# Altium schematic sheet ShieldESCv2-Cuadripolos.SchDoc
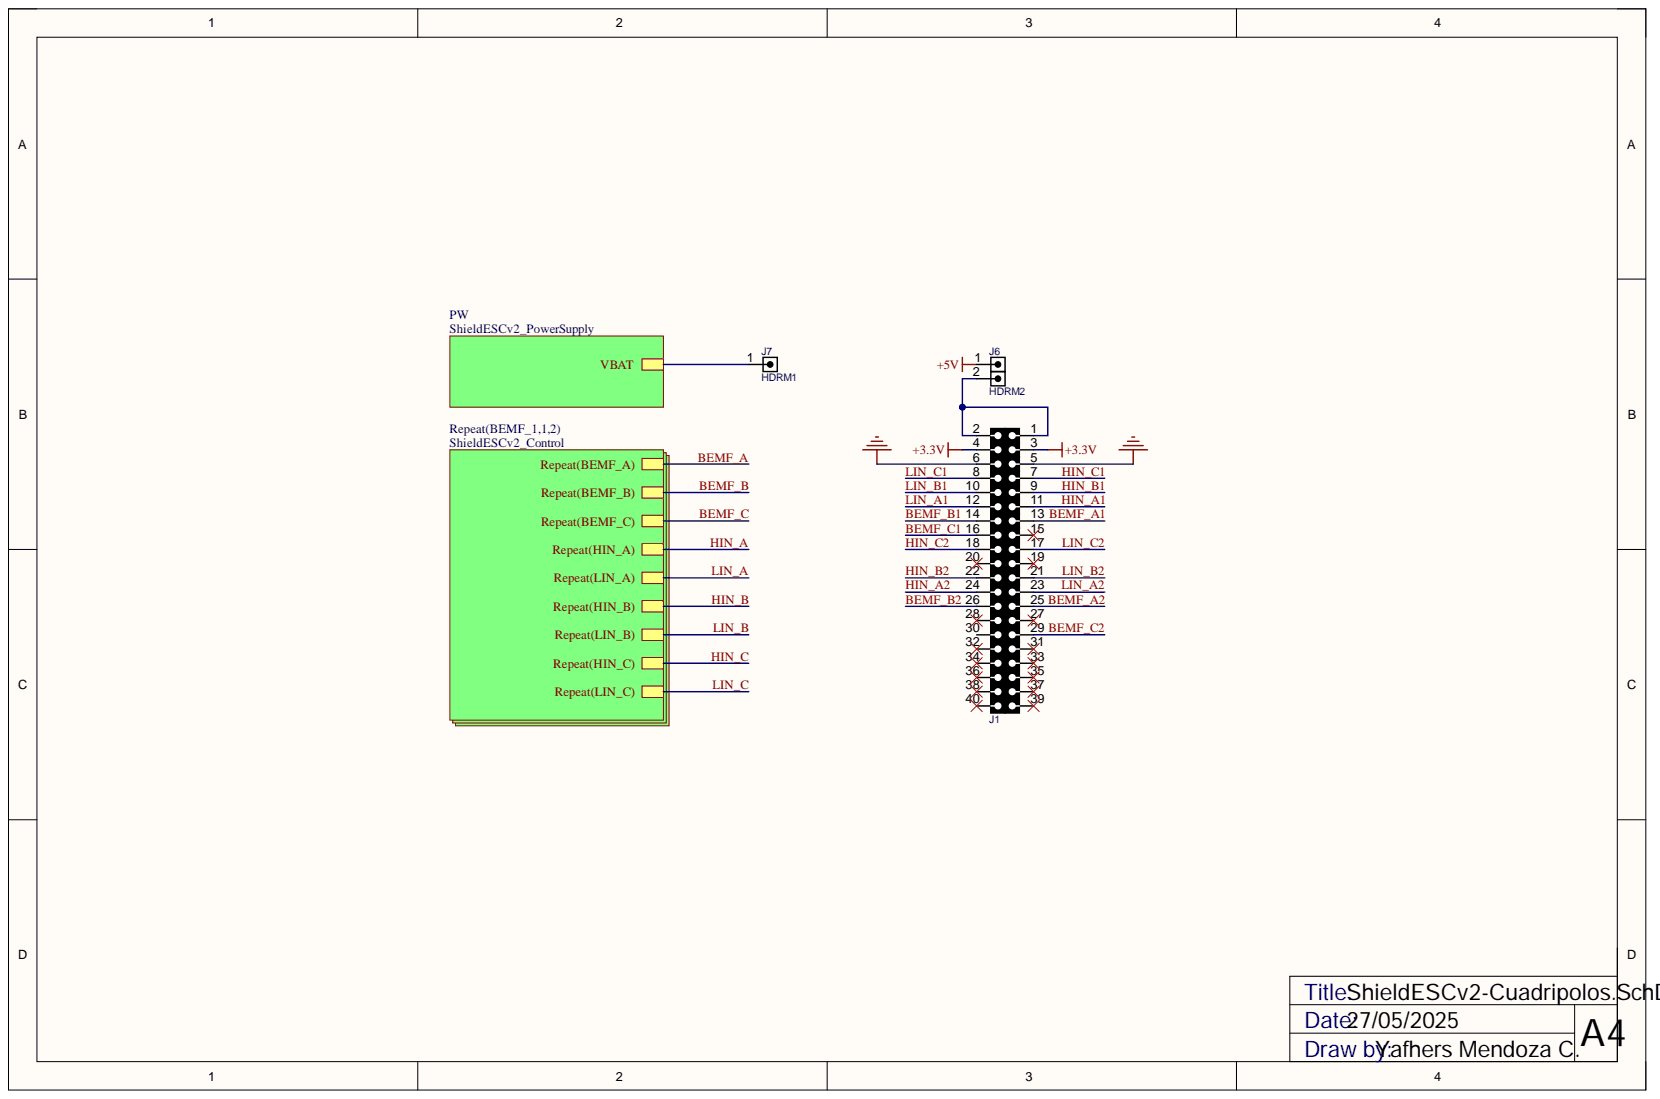
[diagram p0: ShieldESCv2-Cuadripolos.SchDoc]

## Parts
J1  HDRF_2X20  [HDR_F_2X20]  (value not drawn)
J6  HDRM2  [HDR_M_1X2]
J7  HDRM1  [HDR_M_1]

## Nets
+3.3V: J1.3 J1.4
+5V: J6.1
GND: J1.5 J1.6
BEMF_A1: J1.13
BEMF_A2: J1.25
BEMF_B1: J1.14
BEMF_B2: J1.26
BEMF_C1: J1.16
BEMF_C2: J1.29
HIN_A1: J1.11
HIN_A2: J1.24
HIN_B1: J1.9
HIN_B2: J1.22
HIN_C1: J1.7
HIN_C2: J1.18
LIN_A1: J1.12
LIN_A2: J1.23
LIN_B1: J1.10
LIN_B2: J1.21
LIN_C1: J1.8
LIN_C2: J1.17
NetJ1_1: J1.1 J1.2 J6.2
NetJ1_15: J1.15
NetJ1_19: J1.19
NetJ1_20: J1.20
NetJ1_27: J1.27
NetJ1_28: J1.28
NetJ1_30: J1.30
NetJ1_31: J1.31
NetJ1_32: J1.32
NetJ1_33: J1.33
NetJ1_34: J1.34
NetJ1_35: J1.35
NetJ1_36: J1.36
NetJ1_37: J1.37
NetJ1_38: J1.38
NetJ1_39: J1.39
NetJ1_40: J1.40
NetJ7_1: J7.1

## Library data of the parts
J1 = Espadin_F_2x20: Header Stackable 2x20; Component Kind: Standard; Library Name: Conectores.IntLib; VALUE: HDRF_2X20
J6 = Espadin_M_1x02: CONN, HEADER, Male, pitch: 2.54mm, 2POS; Component Kind: Standard; Library Name: Conectores.IntLib; VALUE: HDRM2
J7 = Espadin_M_1x01: CONN, HEADER, Male, pitch: 2.54mm, 1POS; Component Kind: Standard; Library Name: Conectores.IntLib; VALUE: HDRM1
